# Altium schematic sheet SUNNYMultiAnalyzor.SchDoc
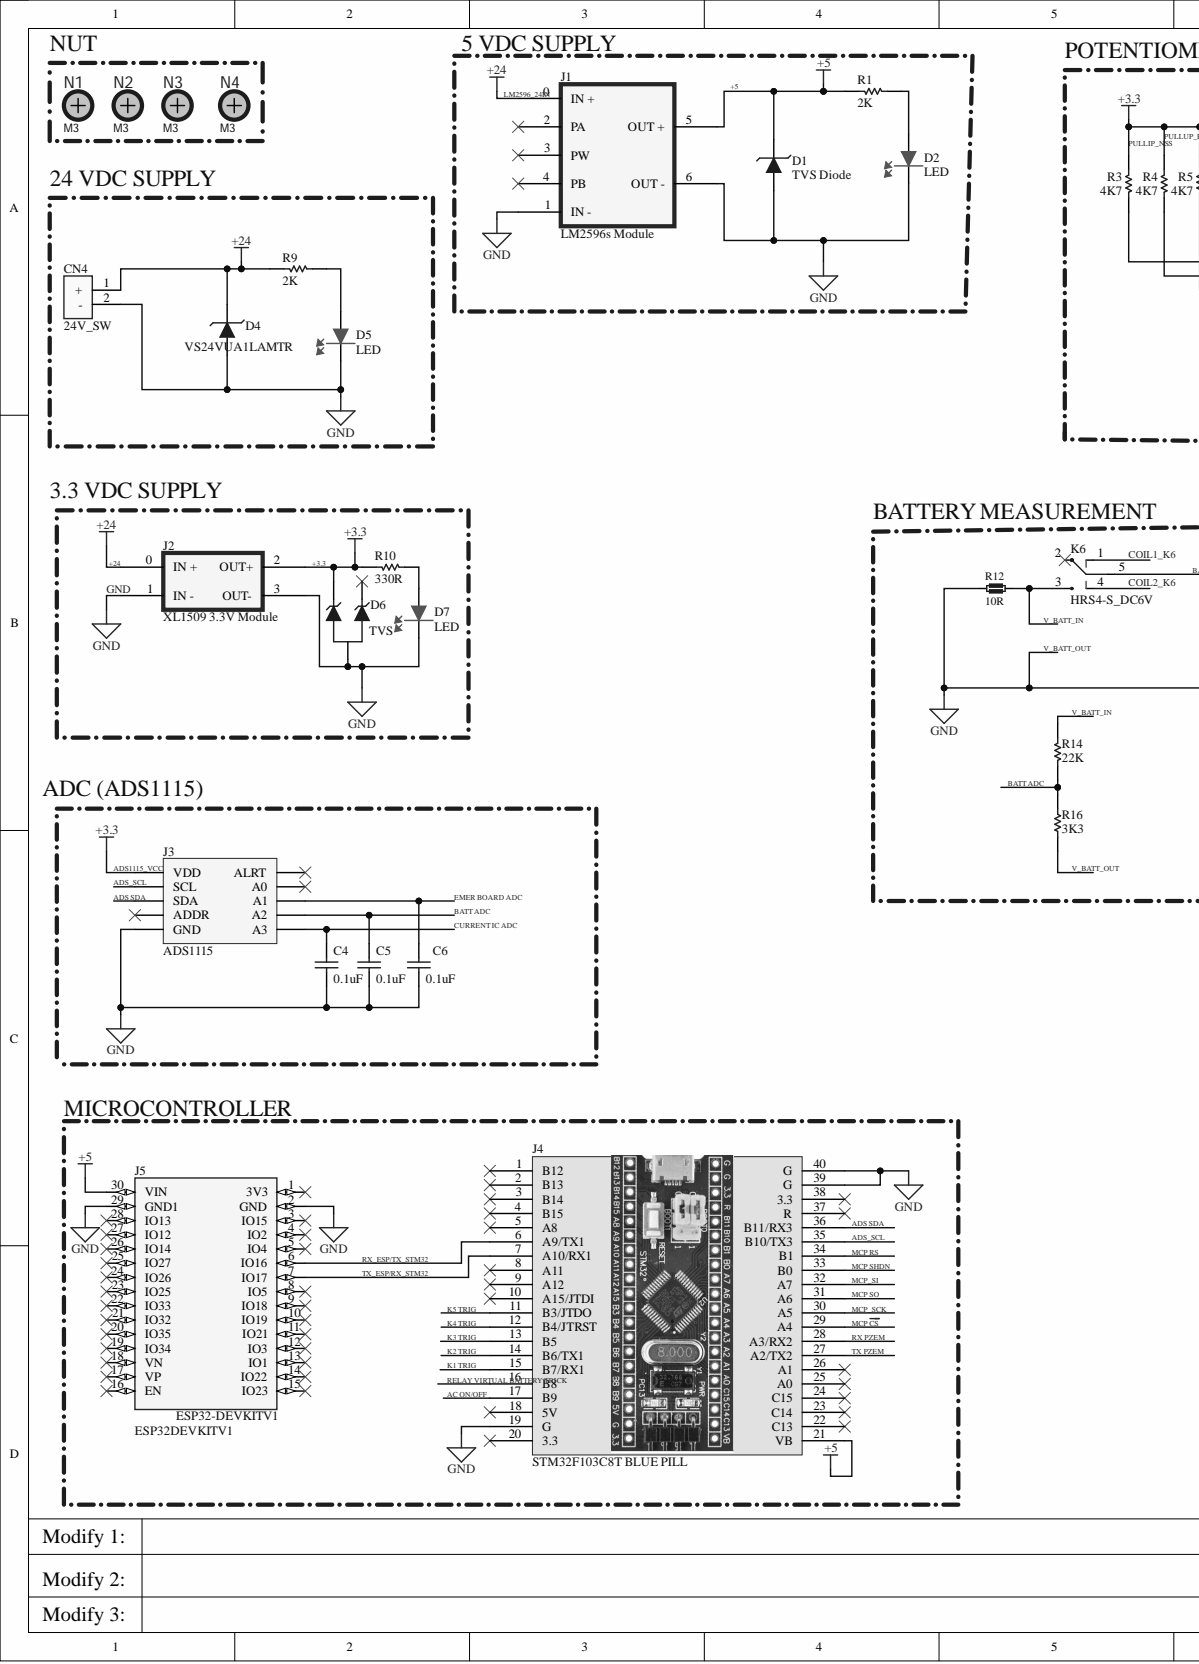
[diagram p0: SUNNYMultiAnalyzor.SchDoc, left]
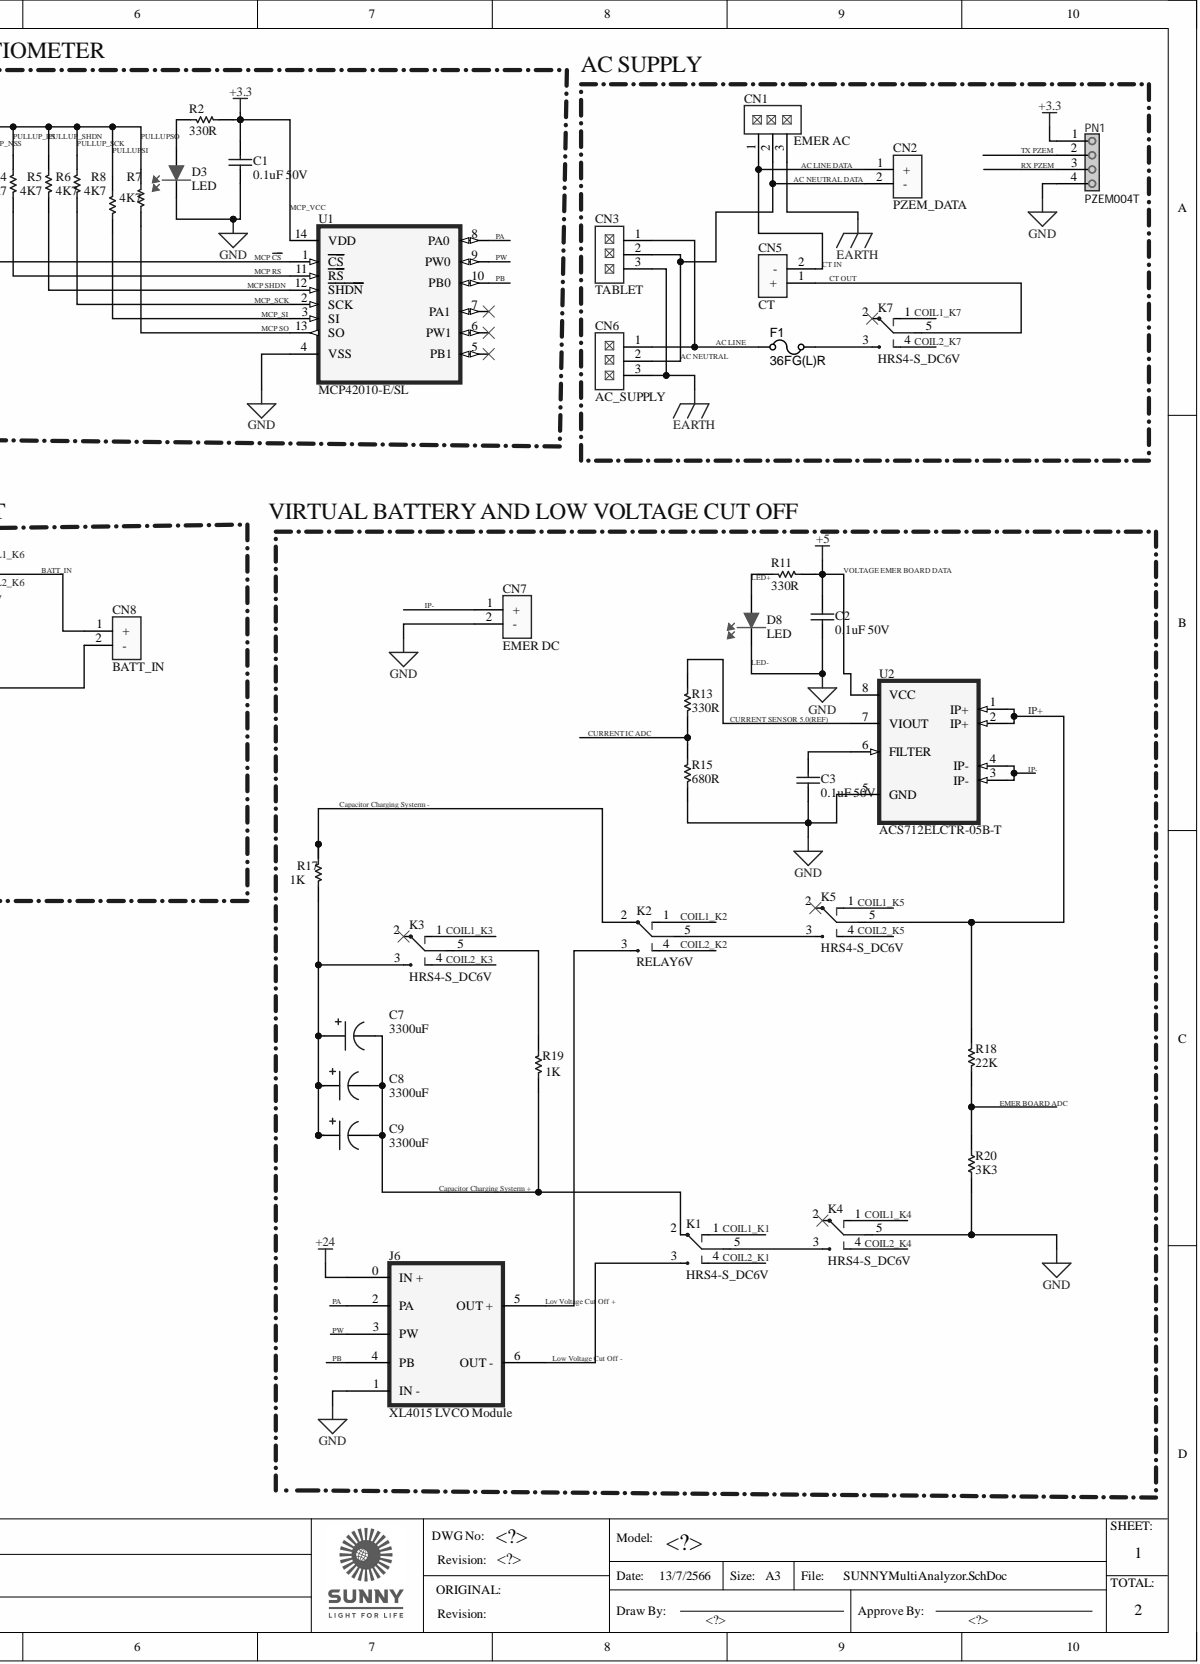
[diagram p1: SUNNYMultiAnalyzor.SchDoc, right]

## Parts
C1  0.1uF 50V  [CAPC2012X135N]
C2  0.1uF 50V  [CAPC2012X135N]
C3  0.1uF 50V  [CAPC2012X135N]
C4  0.1uF  [CAPC2012X135N]
C5  0.1uF  [CAPC2012X135N]
C6  0.1uF  [CAPC2012X135N]
C7  3300uF  [CAP3300]
C8  3300uF  [CAP3300]
C9  3300uF  [CAP3300]
CN1  EMER AC  [CON 3P 3.8mm]
CN2  PZEM_DATA  [Header 2 pin molex]
CN3  TABLET  [CON 3P 3.8mm]
CN4  24V_SW  [Header 2 pin molex]
CN5  CT  [Header 2 pin molex]
CN6  AC_SUPPLY  [CON 3P 3.8mm]
CN7  EMER DC  [Header 2 pin molex]
CN8  BATT_IN  [Header 2 pin molex]
D1  TVS Diode  [SOD-128]
D2  LED  [0805 (1205) LED]
D3  LED  [0805 (1205) LED]
D4  VS24VUA1LAMTR  [SOD-128]
D5  LED  [0805 (1205) LED]
D6  TVS  [SOT95P237X111-3N]
D7  LED  [0805 (1205) LED]
D8  LED  [0805 (1205) LED]
F1  36FG(L)R  [Micro Fuse - L14mm]
J1  LM2596s Module  [LM2596s MODULE]
J2  XL1509 3.3V Module  [XL1509 MODULE]
J3  ADS1115  [BNO080]
J4  STM32F103C8T BLUE PILL  [STM32F103 BLUE PILL]
J5  ESP32DEVKITV1  [ESP32-DEVKITV1_3D]
J6  XL4015 LVCO Module  [XL4015 Module]
K1  HRS4-S_DC6V  [HRS4SDC5V]
K2  RELAY6V  [HRS4SDC5V]
K3  HRS4-S_DC6V  [HRS4SDC5V]
K4  HRS4-S_DC6V  [HRS4SDC5V]
K5  HRS4-S_DC6V  [HRS4SDC5V]
K6  HRS4-S_DC6V  [HRS4SDC5V]
K7  HRS4-S_DC6V  [HRS4SDC5V]
N1  M3  [M3 hole]
N2  M3  [M3 hole]
N3  M3  [M3 hole]
N4  M3  [M3 hole]
PN1  PZEM004T  [1x4P Test PIN]
R1  2K  [0805 Modificado]
R2  330R  [0805 Modificado]
R3  4K7  [0805 Modificado]
R4  4K7  [0805 Modificado]
R5  4K7  [0805 Modificado]
R6  4K7  [0805 Modificado]
R7  4K7  [0805 Modificado]
R8  4K7  [0805 Modificado]
R9  2K  [0805 Modificado]
R10  330R  [0805 Modificado]
R11  330R  [0805 Modificado]
R12  10R  [DUMMY LOAD FOMATION 1]
R13  330R  [0805 Modificado]
R14  22K  [0805 Modificado]
R15  680R  [0603 RESISTOR]
R16  3K3  [1206 RESISTOR]
R17  1K  [0805 Modificado]
R18  22K  [0805 Modificado]
R19  1K  [0805 Modificado]
R20  3K3  [1206 RESISTOR]
U1  MCP42010-E/SL  [SOIC127P600X175-14N]
U2  ACS712ELCTR-05B-T  [SOIC127P600X175-8N]

## Nets
EARTH: CN1.3 CN3.3 CN6.3
+3.3: C1.2 D6.2 J2.2(OUT+) J3.1(VDD) PN1.1 R2.1 R3.1 R4.1 R5.1 R6.1 R7.1 R8.1 R10.2 U1.14(VDD)
+5: C2.2 D1.2 J1.5(OUT_+) J4.21(VB) J5.30(VIN) R1.2 R11.1 U2.8(VCC)
+24: CN4.1 D4.2 J1.0(IN_+) J2.0(IN_+) J6.0(IN_+) R9.2
AC LINE: CN3.1 CN6.1 F1.1
AC LINE DATA: CN1.1 CN2.1 CN5.2
AC NEUTRAL: CN1.2 CN2.2 CN3.2 CN6.2
AC ON/OFF: J4.17(B9)
ADS SDA: J3.4(SDA) J4.36(B11/RX3)
ADS_SCL: J3.3(SCL) J4.35(B10/TX3)
BATT ADC: C5.2 J3.9(A2) R14.1 R16.2
BATT_IN: CN8.1 K6.5
Capacitor Charging Systerm +: C7.N C8.N C9.N K1.2 R19.2
Capacitor Charging Systerm -: K2.2 R17.1
COIL1_K1: K1.1
COIL1_K2: K2.1
COIL1_K3: K3.1
COIL1_K4: K4.1
COIL1_K5: K5.1
COIL1_K6: K6.1
COIL1_K7: K7.1
COIL2_K1: K1.4
COIL2_K2: K2.4
COIL2_K3: K3.4
COIL2_K4: K4.4
COIL2_K5: K5.4
COIL2_K6: K6.4
COIL2_K7: K7.4
CT OUT: CN5.1 K7.5
CURRENT IC ADC: C4.2 J3.10(A3) R13.2 R15.1
CURRENT SENSOR 5.0(REF): R13.1 U2.7(VIOUT)
EMER BOARD ADC: C6.2 J3.8(A1) R18.2 R20.1
GND: C1.1 C2.1 C3.1 C4.1 C5.1 C6.1 CN4.2 CN7.2 CN8.2 D1.1 D2.1 D3.1 D4.1 D5.1 D6.3 D7.1 D8.1 J1.1(IN_-) J1.6(OUT_-) J2.1(IN_-) J2.3(OUT-) J3.2(GND) J4.19(G) J4.39(G) J4.40(G) J5.2(GND) J5.29(GND1) J6.1(IN_-) K4.5 PN1.4 R12.1 R15.2 R16.1 R20.2 U1.4(VSS) U2.5(GND)
IP+: K5.5 R18.1 U2.1(IP+) U2.2(IP+)
IP-: CN7.1 U2.3(IP-) U2.4(IP-)
K1 TRIG: J4.15(B7/RX1)
K2 TRIG: J4.14(B6/TX1)
K3 TRIG: J4.13(B5)
K4 TRIG: J4.12(B4/JTRST)
K5 TRIG: J4.11(B3/JTDO)
LED+: D8.2 R11.2
Lov Voltage Cut Off +: J6.5(OUT_+) K2.3
Low Voltage Cut Off -: J6.6(OUT_-) K1.3
MCP ~{CS}: J4.29(A4) R3.2 U1.1(CS)
MCP RS: J4.34(B1) R4.2 U1.11(RS)
MCP SHDN: J4.33(B0) R5.2 U1.12(SHDN)
MCP SO: J4.31(A6) R7.2 U1.13(SO)
MCP_SCK: J4.30(A5) R6.2 U1.2(SCK)
MCP_SI: J4.32(A7) R8.2 U1.3(SI)
PA: J6.2(PA) U1.8(PA0)
PB: J6.4(PB) U1.10(PB0)
PW: J6.3(PW) U1.9(PW0)
RELAY VIRTUAL BATTERY TRICK: J4.16(B8)
RX PZEM: J4.28(A3/RX2) PN1.3
RX_ESP/TX_STM32: J4.6(A9/TX1) J5.6(IO16)
TX PZEM: J4.27(A2/TX2) PN1.2
TX_ESP/RX_STM32: J4.7(A10/RX1) J5.7(IO17)
V_BATT_IN: K6.3 R12.2 R14.2
NetC3_2: C3.2 U2.6(FILTER)
NetC7_P: C7.P C8.P C9.P K3.3 R17.2
NetD2_2: D2.2 R1.1
NetD3_2: D3.2 R2.2
NetD5_2: D5.2 R9.1
NetD7_2: D7.2 R10.1
NetF1_2: F1.2 K7.3
NetK1_5: K1.5 K4.3
NetK2_5: K2.5 K5.3
NetK3_5: K3.5 R19.1

Not connected: D6 1; J1 2(PA) 3(PW) 4(PB); J3 5(ADDR) 6(ALRT) 7(A0); J4 1(B12) 2(B13) 3(B14) 4(B15) 5(A8) 8(A11) 9(A12) 10(A15/JTDI) 18(5V) 20(3.3) 22(C13) 23(C14) 24(C15) 25(A0) 26(A1) 37(R) 38(3.3); J5 1(3V3) 3(IO15) 4(IO2) 5(IO4) 8(IO5) 9(IO18) 10(IO19) 11(IO21) 12(IO3) 13(IO1) 14(IO22) 15(IO23) 16(EN) 17(VP) 18(VN) 19(IO34) 20(IO35) 21(IO32) 22(IO33) 23(IO25) 24(IO26) 25(IO27) 26(IO14) 27(IO12) 28(IO13); K3 2; K4 2; K5 2; K6 2; K7 2; U1 5(PB1) 6(PW1) 7(PA1)

## Library data of the parts
C1-C6 = Capacitor SMD Ceramic Chip Cap 0.1uF 50V (0805) (AVX): RMPN: RMELCCAPSC001; Value: 0.1uF, 50V Ceramic; Component Kind: Standard; Description: Capacitor SMD Ceramic Chip Cap 0.1uF 50V (0805) (AVX); Published: 28 April 2014; RM DESC: Capacitor SMD Ceramic Chip Cap 0.1uF 50V (0805) (AVX); Created by: Zion Blue; Library Name: Control INV-V21.SCHLIB; Publisher: Cynetik Group
C7-C9 = Electrolytic Capacitor: 3300 uF / 50 Vdc; Component Kind: Standard; Library Name: PCB_Project_1.SCHLIB
CN1 CN3 CN6 = Con 112002ST/RH 3.96mm 2P V-PLUG_1: Connector 3.9mm. 3PINS WAFER STRAIGHT PIN (MALE); Manufacturer: GTK; RMPN: RMELCCNT006; ESPN: 0007-0932-2; M DESC: WAFER Connector 2 Pins,Pitch 3.96mm, Straight Type; RM DESC: Connector 3.9mm. 2PINS WAFER STRAIGHT PIN (MALE); Component Kind: Standard; ComponentLink1Description: ES LINK; ComponentLink1URL: https://www.es.co.th/detail.asp?Prod=000709322; Library Name: MCU POWER BOARD.SchLib; MPN: 112002ST/RH
CN2 CN4 CN5 CN7 CN8 = Header LIGHT: Connector 3.96MM 02 PIN WAFER STRAIGHTPIN (MALE); Component Kind: Standard; Library Name: MCU POWER BOARD.SchLib
D1 = TVS Diode VS6V0UA1LAMTR: TVS Diode VS6V0UA1LAMTR . Breakdown Voltage = 7.02 V , Clamping Voltage 10.3 V , Working Reverse Standoff Voltage 6V; Code_JEDEC: DO-204-AL; Component Kind: Standard; ComponentLink1Description: Manufacturer Link; ComponentLink1URL: http://www.fairchildsemi.com/; ComponentLink2Description: Datasheet; ComponentLink2URL: http://www.fairchildsemi.com/ds/1N%2F1N4001.pdf; DatasheetVersion: 1998; LatestRevisionDate: 17-Jul-2002; LatestRevisionNote: Re-released for DXP Platform.; Library Name: PCB_Project_1.SCHLIB; PackageDescription: Diode, Axial; Body 5.2 x 2.7 mm (LxDia. max), Lead Dia. 0.86 mm (max); PackageReference: DO-41; PackageVersion: Aug-1999; Published: 8-Jun-2000; Publisher: Altium Limited
D2 D3 D5 D7 D8 = LED RED (SMD): LED SMD  mcd,Red Color Red Diffused Lens; Component Kind: Standard; Library Name: PCB_Project_1.SCHLIB
D4 = TVS Diode VS24VUA1LAMTR: TVS Diode VS24VUA1LAMTR . Breakdown Voltage = 28.1 V , Clamping Voltage 38.9 V , Working Reverse Standoff Voltage 24V; Code_JEDEC: DO-204-AL; Component Kind: Standard; ComponentLink1Description: Manufacturer Link; ComponentLink1URL: http://www.fairchildsemi.com/; ComponentLink2Description: Datasheet; ComponentLink2URL: http://www.fairchildsemi.com/ds/1N%2F1N4001.pdf; DatasheetVersion: 1998; LatestRevisionDate: 17-Jul-2002; LatestRevisionNote: Re-released for DXP Platform.; Library Name: PCB_Project_1.SCHLIB; PackageDescription: Diode, Axial; Body 5.2 x 2.7 mm (LxDia. max), Lead Dia. 0.86 mm (max); PackageReference: DO-41; PackageVersion: Aug-1999; Published: 8-Jun-2000; Publisher: Altium Limited
D6 = TVS Diode MMBZ6V8ALT1G: 46V Clamp 870mA Ipp Tvs Diode Surface Mount SOT-23-3 (TO-236); Availability: Unavailable; Component Kind: Standard; Library Name: PCB_Project_1.SCHLIB; MP: MMBZ6V8ALT1G; Package: None; Price: None
F1 = Fuse 36FG(L)R 1A On pcb: Fuse Microfuse, 1A/250Vac, Fast Acting (Normal Blow) P/N : 36FG(L)R (SLEEK); RMPN: RMELCFUSA001; ESPN: 0562-0001-0; Revised: Zion Blue; RM DESC: Fuse หางหนู Microfuse, 1A/250Vac, Fast Acting (Normal Blow) P/N : 36FG(L)R (SLEEK); Value: 1A, 250Vac; Component Kind: Standard; ComponentLink1Description: Link ES; ComponentLink1URL: https://www.es.co.th/detail.asp?prod=056200010; ComponentLink2Description: Datasheet; ComponentLink2URL: https://www.es.co.th/Schemetic/PDF/36FG-SLEEK.PDF; Library Name: MCU POWER BOARD.SchLib; Manufacturer: SLEEK; MPN: 36FG(L)R; Published: 14-May-2014; Publisher: Cynetik Group
J1 = LM2596s Module 5A: LM2596s Module step down converter; Component Kind: Standard; Library Name: PCB_Project_1.SCHLIB
J2 = XL1509 Module: XL1509 Module step down converter; Component Kind: Standard; Library Name: PCB_Project_1.SCHLIB
J3 = ADS1115: ADC Sensor; Component Kind: Standard; LatestRevisionDate: 17-Jul-2002; LatestRevisionNote: Re-released for DXP Platform.; Signal Integrity: Connector; Library Name: SchlibBruno.SchLib; Publisher: Altium Limited
J4 = STM32 BULE PILL: STM32F103C8T BULE PILL; Component Kind: Standard; Library Name: PCB_Project_1.SCHLIB
J5 = ESP32DEVKITV1: Dual core, Wi-Fi: 2.4 GHz up to 150 Mbits/s,BLE (Bluetooth Low Energy) and legacy Bluetooth, 32 bits, Up to 240 MHz; MF: Do it; Availability: Unavailable; Check_prices: https://www.snapeda.com/parts/ESP32%20DEVKIT%20V1/Do%20it/view-part/5659245/?ref=eda; Component Kind: Standard; MP: ESP32 DEVKIT V1; Package: Package; Price: None; SnapEDA_Link: https://www.snapeda.com/parts/ESP32%20DEVKIT%20V1/Do%20it/view-part/5659245/?ref=snap; Library Name: SchlibBruno.SchLib
J6 = XL4015 Module 5A: XL4015 Module step down converter for Low Voltage Cut Off Check(LVCO); Component Kind: Standard; Library Name: PCB_Project_1.SCHLIB
K1-K7 = HRS4-S_DC5V: Relay or Contactor; Manufacturer_Name: MULTICOMP; Manufacturer_Part_Number: HRS4-S DC5V; Component Kind: Standard; Datasheet Link: http://www.farnell.com/datasheets/1306711.pdf; Height: 16mm; Library Name: SamacSys.SchLib
N1-N4 = M3 hole: น็อต JT หัวร่ม สกรูมิลสี่แฉก M3x6; RMPN: RMRMANUTCJTZ011; Component Kind: Standard; Library Name: Control INV-V21.SCHLIB
PN1 = PIN Header 1X4: Connector Pin Header 4 Pins,Pitch 2.54mm. P/N : PHSS04G10/RH (GTK); Component Kind: Standard; ComponentLink1Description: Link ES; ComponentLink1URL: https://www.es.co.th/detail.asp?Prod=000703471; ComponentLink2Description: Datasheet; ComponentLink2URL: https://www.es.co.th/Schemetic/PDF/PHSSXXG10.PDF; Library Name: Control INV-V21.SCHLIB
R1-R11 R13-R20 = Resistor SMD 330R +/- 1% (CHIP): 'RES SMD 330 OHM 5% 1/8W 0805/0805W8F3300T5E; RMPN: RMELCRSTS010; Component Kind: Standard; Library Name: MCU POWER BOARD.SchLib
R12 = Dummy Load: 10 OHM , 40 W . Made by Parallel of 2 Resistor 20 OHM 20 W; Component Kind: Standard; Library Name: PCB_Project_1.SCHLIB
U1 = MCP42010-E/SL: Digital Potentiometer 10k Ohm 2 Circuit 256 Taps SPI Interface 14-SOIC; MF: Microchip; Availability: Unavailable; Component Kind: Standard; Library Name: PCB_Project_1.SCHLIB; MP: MCP42010-E/SL; Package: SOIC-14 Microchip; Price: None; SnapEDA_Link: https://www.snapeda.com/parts/MCP42010-E/SL/Microchip/view-part/386175/?ref=snap
U2 = ACS712ELCTR-05B-T: Current Sensor 5A 1 Channel Hall Effect, Open Loop Bidirectional 8-SOIC (0.154, 3.90mm Width); MF: Allegro MicroSystems LLC; Availability: In Stock; Check_prices: https://www.snapeda.com/parts/ACS712ELCTR-05B-T/Allegro+MicroSystems+LLC/view-part/?ref=eda; Component Kind: Standard; Library Name: ACS712ELCTR-05B-T.SchLib; MP: ACS712ELCTR-05B-T; Package: SOIC-8 Allegro MicroSystems LLC; Price: None; Purchase-URL: https://pricing.snapeda.com/search/part/ACS712ELCTR-05B-T/?ref=eda; SnapEDA_Link: https://www.snapeda.com/parts/ACS712ELCTR-05B-T/Allegro+MicroSystems+LLC/view-part/?ref=snap
